# Altium schematic sheet led_clock-LEDB.SchDoc
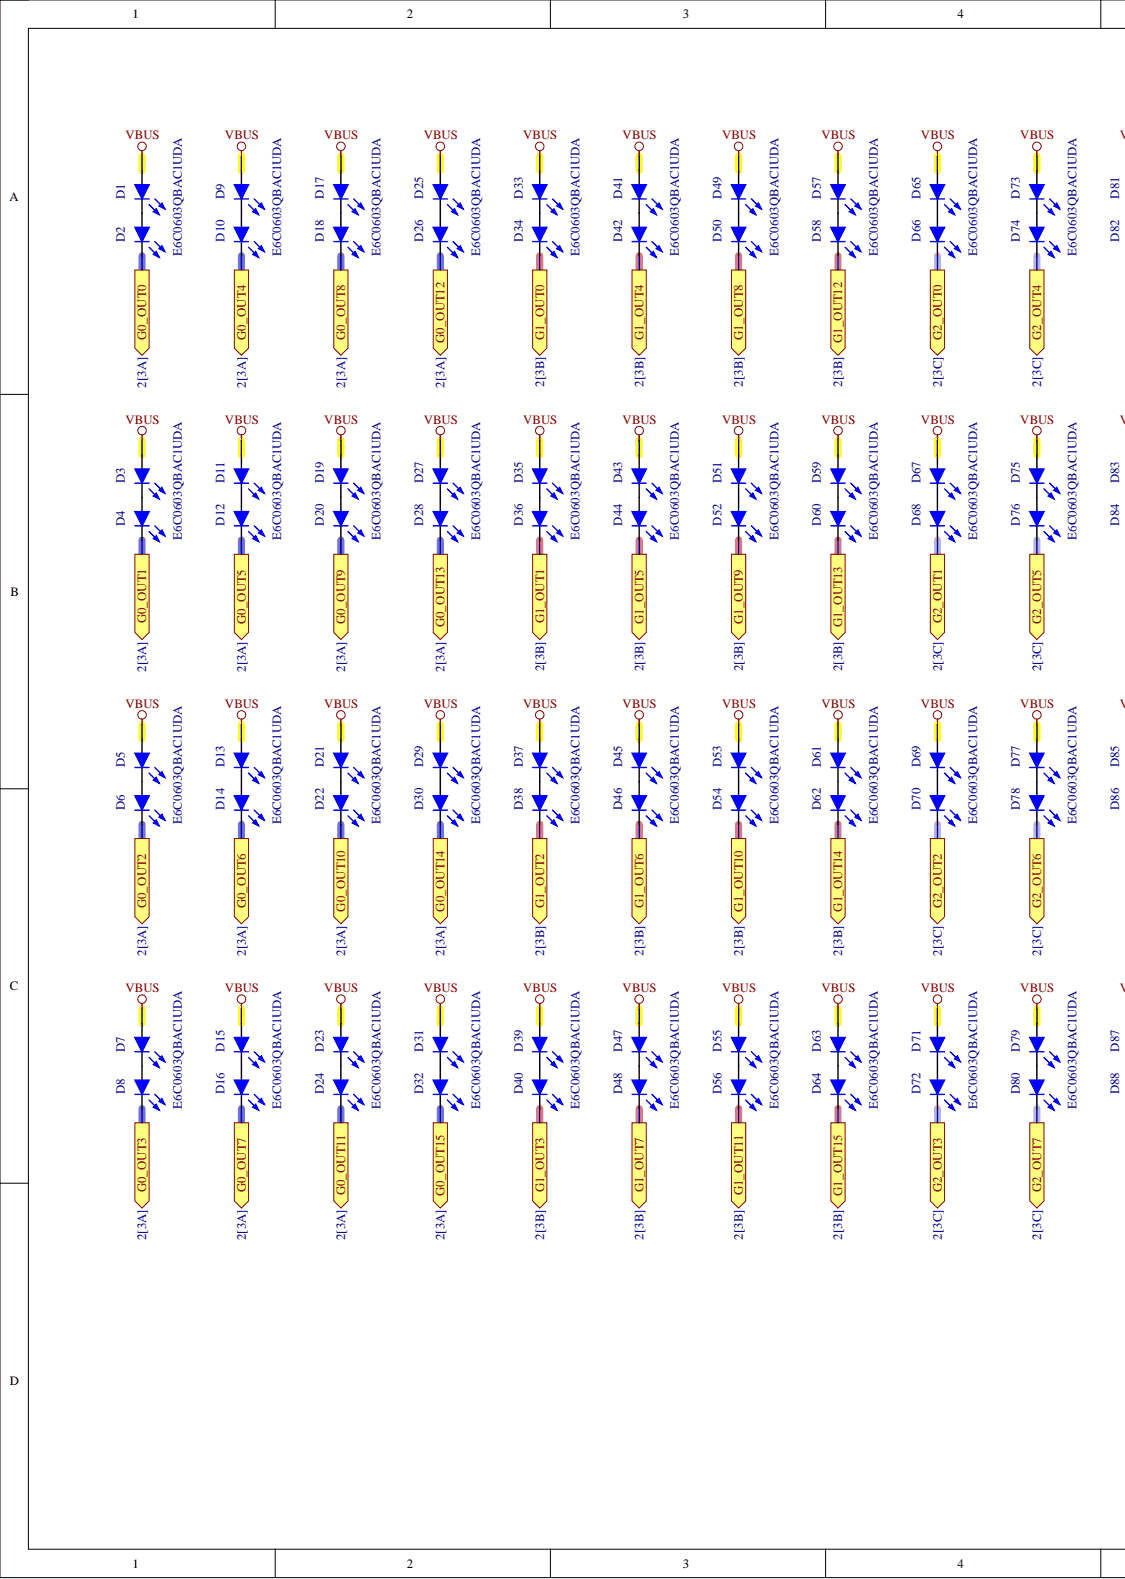
[diagram p0: led_clock-LEDB.SchDoc, left]
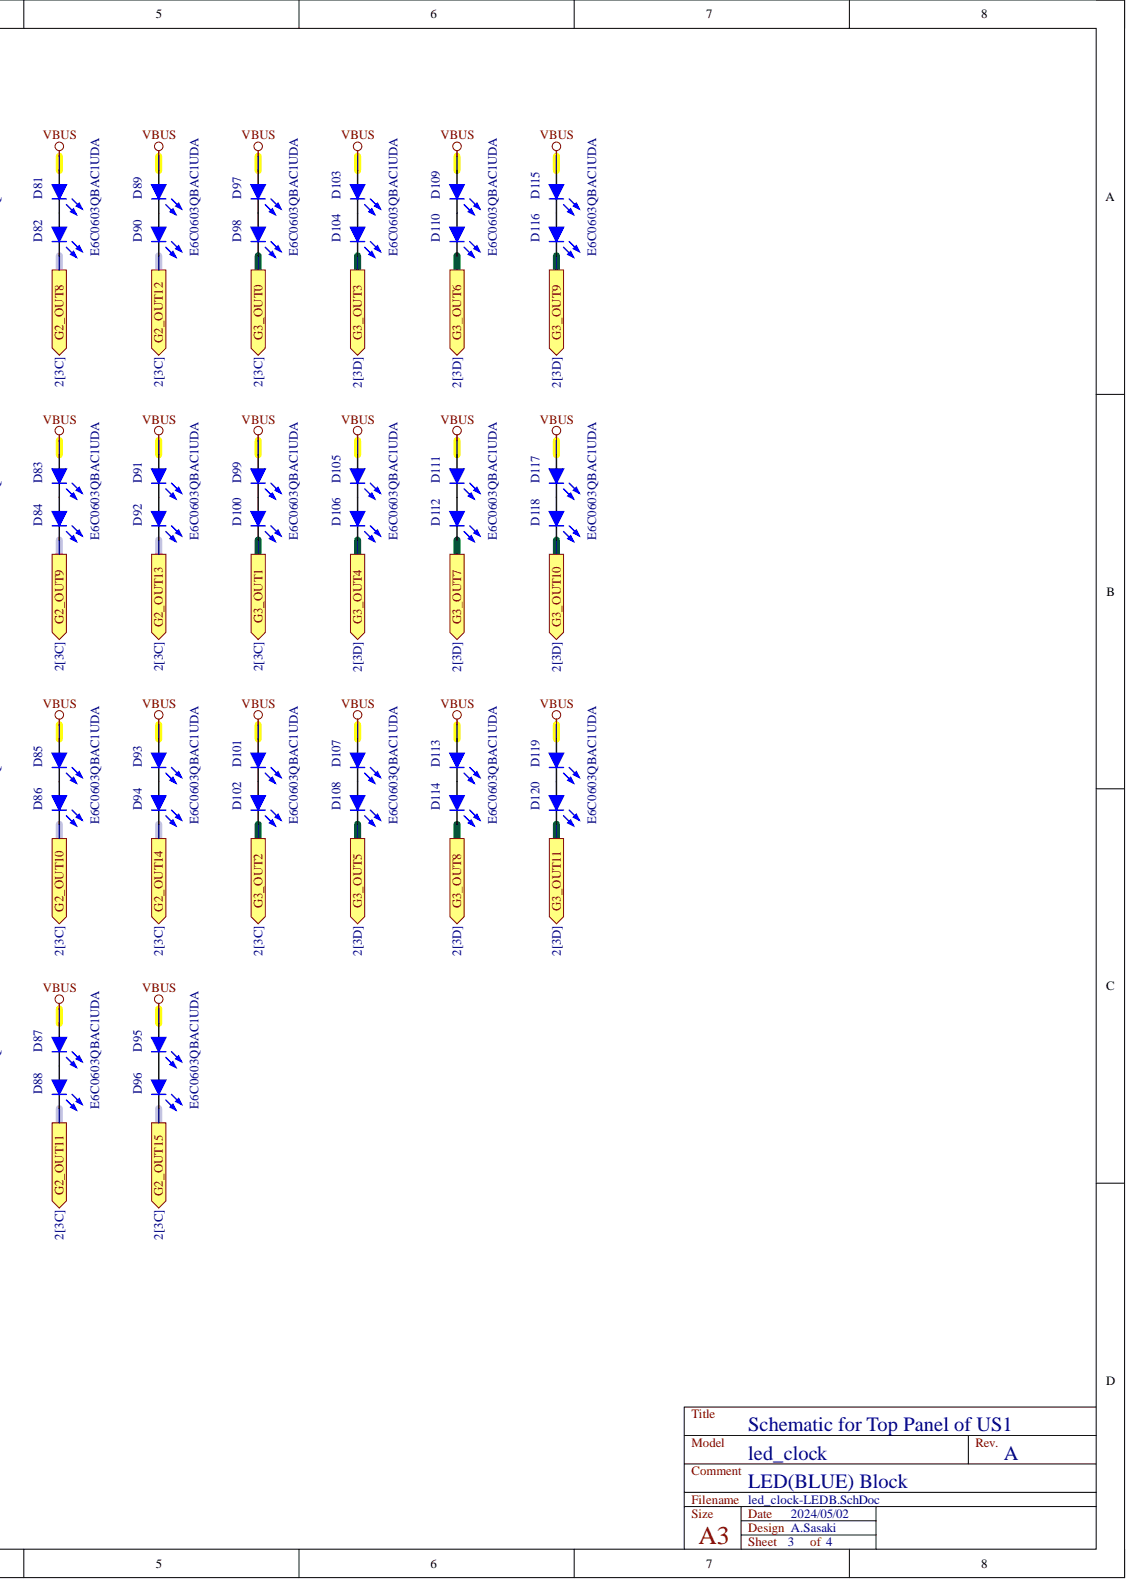
[diagram p1: led_clock-LEDB.SchDoc, right]

## Parts
D1  E6C0603QBAC1UDA  [LED1608X04N_ROTATED]
D2  E6C0603QBAC1UDA  [LED1608X04N_ROTATED]
D3  E6C0603QBAC1UDA  [LED1608X04N_ROTATED]
D4  E6C0603QBAC1UDA  [LED1608X04N_ROTATED]
D5  E6C0603QBAC1UDA  [LED1608X04N_ROTATED]
D6  E6C0603QBAC1UDA  [LED1608X04N_ROTATED]
D7  E6C0603QBAC1UDA  [LED1608X04N_ROTATED]
D8  E6C0603QBAC1UDA  [LED1608X04N_ROTATED]
D9  E6C0603QBAC1UDA  [LED1608X04N_ROTATED]
D10  E6C0603QBAC1UDA  [LED1608X04N_ROTATED]
D11  E6C0603QBAC1UDA  [LED1608X04N_ROTATED]
D12  E6C0603QBAC1UDA  [LED1608X04N_ROTATED]
D13  E6C0603QBAC1UDA  [LED1608X04N_ROTATED]
D14  E6C0603QBAC1UDA  [LED1608X04N_ROTATED]
D15  E6C0603QBAC1UDA  [LED1608X04N_ROTATED]
D16  E6C0603QBAC1UDA  [LED1608X04N_ROTATED]
D17  E6C0603QBAC1UDA  [LED1608X04N_ROTATED]
D18  E6C0603QBAC1UDA  [LED1608X04N_ROTATED]
D19  E6C0603QBAC1UDA  [LED1608X04N_ROTATED]
D20  E6C0603QBAC1UDA  [LED1608X04N_ROTATED]
D21  E6C0603QBAC1UDA  [LED1608X04N_ROTATED]
D22  E6C0603QBAC1UDA  [LED1608X04N_ROTATED]
D23  E6C0603QBAC1UDA  [LED1608X04N_ROTATED]
D24  E6C0603QBAC1UDA  [LED1608X04N_ROTATED]
D25  E6C0603QBAC1UDA  [LED1608X04N_ROTATED]
D26  E6C0603QBAC1UDA  [LED1608X04N_ROTATED]
D27  E6C0603QBAC1UDA  [LED1608X04N_ROTATED]
D28  E6C0603QBAC1UDA  [LED1608X04N_ROTATED]
D29  E6C0603QBAC1UDA  [LED1608X04N_ROTATED]
D30  E6C0603QBAC1UDA  [LED1608X04N_ROTATED]
D31  E6C0603QBAC1UDA  [LED1608X04N_ROTATED]
D32  E6C0603QBAC1UDA  [LED1608X04N_ROTATED]
D33  E6C0603QBAC1UDA  [LED1608X04N_ROTATED]
D34  E6C0603QBAC1UDA  [LED1608X04N_ROTATED]
D35  E6C0603QBAC1UDA  [LED1608X04N_ROTATED]
D36  E6C0603QBAC1UDA  [LED1608X04N_ROTATED]
D37  E6C0603QBAC1UDA  [LED1608X04N_ROTATED]
D38  E6C0603QBAC1UDA  [LED1608X04N_ROTATED]
D39  E6C0603QBAC1UDA  [LED1608X04N_ROTATED]
D40  E6C0603QBAC1UDA  [LED1608X04N_ROTATED]
D41  E6C0603QBAC1UDA  [LED1608X04N_ROTATED]
D42  E6C0603QBAC1UDA  [LED1608X04N_ROTATED]
D43  E6C0603QBAC1UDA  [LED1608X04N_ROTATED]
D44  E6C0603QBAC1UDA  [LED1608X04N_ROTATED]
D45  E6C0603QBAC1UDA  [LED1608X04N_ROTATED]
D46  E6C0603QBAC1UDA  [LED1608X04N_ROTATED]
D47  E6C0603QBAC1UDA  [LED1608X04N_ROTATED]
D48  E6C0603QBAC1UDA  [LED1608X04N_ROTATED]
D49  E6C0603QBAC1UDA  [LED1608X04N_ROTATED]
D50  E6C0603QBAC1UDA  [LED1608X04N_ROTATED]
D51  E6C0603QBAC1UDA  [LED1608X04N_ROTATED]
D52  E6C0603QBAC1UDA  [LED1608X04N_ROTATED]
D53  E6C0603QBAC1UDA  [LED1608X04N_ROTATED]
D54  E6C0603QBAC1UDA  [LED1608X04N_ROTATED]
D55  E6C0603QBAC1UDA  [LED1608X04N_ROTATED]
D56  E6C0603QBAC1UDA  [LED1608X04N_ROTATED]
D57  E6C0603QBAC1UDA  [LED1608X04N_ROTATED]
D58  E6C0603QBAC1UDA  [LED1608X04N_ROTATED]
D59  E6C0603QBAC1UDA  [LED1608X04N_ROTATED]
D60  E6C0603QBAC1UDA  [LED1608X04N_ROTATED]
D61  E6C0603QBAC1UDA  [LED1608X04N_ROTATED]
D62  E6C0603QBAC1UDA  [LED1608X04N_ROTATED]
D63  E6C0603QBAC1UDA  [LED1608X04N_ROTATED]
D64  E6C0603QBAC1UDA  [LED1608X04N_ROTATED]
D65  E6C0603QBAC1UDA  [LED1608X04N_ROTATED]
D66  E6C0603QBAC1UDA  [LED1608X04N_ROTATED]
D67  E6C0603QBAC1UDA  [LED1608X04N_ROTATED]
D68  E6C0603QBAC1UDA  [LED1608X04N_ROTATED]
D69  E6C0603QBAC1UDA  [LED1608X04N_ROTATED]
D70  E6C0603QBAC1UDA  [LED1608X04N_ROTATED]
D71  E6C0603QBAC1UDA  [LED1608X04N_ROTATED]
D72  E6C0603QBAC1UDA  [LED1608X04N_ROTATED]
D73  E6C0603QBAC1UDA  [LED1608X04N_ROTATED]
D74  E6C0603QBAC1UDA  [LED1608X04N_ROTATED]
D75  E6C0603QBAC1UDA  [LED1608X04N_ROTATED]
D76  E6C0603QBAC1UDA  [LED1608X04N_ROTATED]
D77  E6C0603QBAC1UDA  [LED1608X04N_ROTATED]
D78  E6C0603QBAC1UDA  [LED1608X04N_ROTATED]
D79  E6C0603QBAC1UDA  [LED1608X04N_ROTATED]
D80  E6C0603QBAC1UDA  [LED1608X04N_ROTATED]
D81  E6C0603QBAC1UDA  [LED1608X04N_ROTATED]
D82  E6C0603QBAC1UDA  [LED1608X04N_ROTATED]
D83  E6C0603QBAC1UDA  [LED1608X04N_ROTATED]
D84  E6C0603QBAC1UDA  [LED1608X04N_ROTATED]
D85  E6C0603QBAC1UDA  [LED1608X04N_ROTATED]
D86  E6C0603QBAC1UDA  [LED1608X04N_ROTATED]
D87  E6C0603QBAC1UDA  [LED1608X04N_ROTATED]
D88  E6C0603QBAC1UDA  [LED1608X04N_ROTATED]
D89  E6C0603QBAC1UDA  [LED1608X04N_ROTATED]
D90  E6C0603QBAC1UDA  [LED1608X04N_ROTATED]
D91  E6C0603QBAC1UDA  [LED1608X04N_ROTATED]
D92  E6C0603QBAC1UDA  [LED1608X04N_ROTATED]
D93  E6C0603QBAC1UDA  [LED1608X04N_ROTATED]
D94  E6C0603QBAC1UDA  [LED1608X04N_ROTATED]
D95  E6C0603QBAC1UDA  [LED1608X04N_ROTATED]
D96  E6C0603QBAC1UDA  [LED1608X04N_ROTATED]
D97  E6C0603QBAC1UDA  [LED1608X04N_ROTATED]
D98  E6C0603QBAC1UDA  [LED1608X04N_ROTATED]
D99  E6C0603QBAC1UDA  [LED1608X04N_ROTATED]
D100  E6C0603QBAC1UDA  [LED1608X04N_ROTATED]
D101  E6C0603QBAC1UDA  [LED1608X04N_ROTATED]
D102  E6C0603QBAC1UDA  [LED1608X04N_ROTATED]
D103  E6C0603QBAC1UDA  [LED1608X04N_ROTATED]
D104  E6C0603QBAC1UDA  [LED1608X04N_ROTATED]
D105  E6C0603QBAC1UDA  [LED1608X04N_ROTATED]
D106  E6C0603QBAC1UDA  [LED1608X04N_ROTATED]
D107  E6C0603QBAC1UDA  [LED1608X04N_ROTATED]
D108  E6C0603QBAC1UDA  [LED1608X04N_ROTATED]
D109  E6C0603QBAC1UDA  [LED1608X04N_ROTATED]
D110  E6C0603QBAC1UDA  [LED1608X04N_ROTATED]
D111  E6C0603QBAC1UDA  [LED1608X04N_ROTATED]
D112  E6C0603QBAC1UDA  [LED1608X04N_ROTATED]
D113  E6C0603QBAC1UDA  [LED1608X04N_ROTATED]
D114  E6C0603QBAC1UDA  [LED1608X04N_ROTATED]
D115  E6C0603QBAC1UDA  [LED1608X04N_ROTATED]
D116  E6C0603QBAC1UDA  [LED1608X04N_ROTATED]
D117  E6C0603QBAC1UDA  [LED1608X04N_ROTATED]
D118  E6C0603QBAC1UDA  [LED1608X04N_ROTATED]
D119  E6C0603QBAC1UDA  [LED1608X04N_ROTATED]
D120  E6C0603QBAC1UDA  [LED1608X04N_ROTATED]

## Ports
G0_OUT0, G0_OUT1, G0_OUT2, G0_OUT3, G0_OUT4, G0_OUT5, G0_OUT6, G0_OUT7, G0_OUT8, G0_OUT9, G0_OUT10, G0_OUT11, G0_OUT12, G0_OUT13, G0_OUT14, G0_OUT15, G1_OUT0, G1_OUT1, G1_OUT2, G1_OUT3, G1_OUT4, G1_OUT5, G1_OUT6, G1_OUT7, G1_OUT8, G1_OUT9, G1_OUT10, G1_OUT11, G1_OUT12, G1_OUT13, G1_OUT14, G1_OUT15, G2_OUT0, G2_OUT1, G2_OUT2, G2_OUT3, G2_OUT4, G2_OUT5, G2_OUT6, G2_OUT7, G2_OUT8, G2_OUT9, G2_OUT10, G2_OUT11, G2_OUT12, G2_OUT13, G2_OUT14, G2_OUT15, G3_OUT0, G3_OUT1, G3_OUT2, G3_OUT3, G3_OUT4, G3_OUT5, G3_OUT6, G3_OUT7, G3_OUT8, G3_OUT9, G3_OUT10, G3_OUT11

## Nets
VBUS: D1.1 D3.1 D5.1 D7.1 D9.1 D11.1 D13.1 D15.1 D17.1 D19.1 D21.1 D23.1 D25.1 D27.1 D29.1 D31.1 D33.1 D35.1 D37.1 D39.1 D41.1 D43.1 D45.1 D47.1 D49.1 D51.1 D53.1 D55.1 D57.1 D59.1 D61.1 D63.1 D65.1 D67.1 D69.1 D71.1 D73.1 D75.1 D77.1 D79.1 D81.1 D83.1 D85.1 D87.1 D89.1 D91.1 D93.1 D95.1 D97.1 D99.1 D101.1 D103.1 D105.1 D107.1 D109.1 D111.1 D113.1 D115.1 D117.1 D119.1
G0_OUT0: D2.2 ; port G0_OUT0
G0_OUT1: D4.2 ; port G0_OUT1
G0_OUT2: D6.2 ; port G0_OUT2
G0_OUT3: D8.2 ; port G0_OUT3
G0_OUT4: D10.2 ; port G0_OUT4
G0_OUT5: D12.2 ; port G0_OUT5
G0_OUT6: D14.2 ; port G0_OUT6
G0_OUT7: D16.2 ; port G0_OUT7
G0_OUT8: D18.2 ; port G0_OUT8
G0_OUT9: D20.2 ; port G0_OUT9
G0_OUT10: D22.2 ; port G0_OUT10
G0_OUT11: D24.2 ; port G0_OUT11
G0_OUT12: D26.2 ; port G0_OUT12
G0_OUT13: D28.2 ; port G0_OUT13
G0_OUT14: D30.2 ; port G0_OUT14
G0_OUT15: D32.2 ; port G0_OUT15
G1_OUT0: D34.2 ; port G1_OUT0
G1_OUT1: D36.2 ; port G1_OUT1
G1_OUT2: D38.2 ; port G1_OUT2
G1_OUT3: D40.2 ; port G1_OUT3
G1_OUT4: D42.2 ; port G1_OUT4
G1_OUT5: D44.2 ; port G1_OUT5
G1_OUT6: D46.2 ; port G1_OUT6
G1_OUT7: D48.2 ; port G1_OUT7
G1_OUT8: D50.2 ; port G1_OUT8
G1_OUT9: D52.2 ; port G1_OUT9
G1_OUT10: D54.2 ; port G1_OUT10
G1_OUT11: D56.2 ; port G1_OUT11
G1_OUT12: D58.2 ; port G1_OUT12
G1_OUT13: D60.2 ; port G1_OUT13
G1_OUT14: D62.2 ; port G1_OUT14
G1_OUT15: D64.2 ; port G1_OUT15
G2_OUT0: D66.2 ; port G2_OUT0
G2_OUT1: D68.2 ; port G2_OUT1
G2_OUT2: D70.2 ; port G2_OUT2
G2_OUT3: D72.2 ; port G2_OUT3
G2_OUT4: D74.2 ; port G2_OUT4
G2_OUT5: D76.2 ; port G2_OUT5
G2_OUT6: D78.2 ; port G2_OUT6
G2_OUT7: D80.2 ; port G2_OUT7
G2_OUT8: D82.2 ; port G2_OUT8
G2_OUT9: D84.2 ; port G2_OUT9
G2_OUT10: D86.2 ; port G2_OUT10
G2_OUT11: D88.2 ; port G2_OUT11
G2_OUT12: D90.2 ; port G2_OUT12
G2_OUT13: D92.2 ; port G2_OUT13
G2_OUT14: D94.2 ; port G2_OUT14
G2_OUT15: D96.2 ; port G2_OUT15
G3_OUT0: D98.2 ; port G3_OUT0
G3_OUT1: D100.2 ; port G3_OUT1
G3_OUT2: D102.2 ; port G3_OUT2
G3_OUT3: D104.2 ; port G3_OUT3
G3_OUT4: D106.2 ; port G3_OUT4
G3_OUT5: D108.2 ; port G3_OUT5
G3_OUT6: D110.2 ; port G3_OUT6
G3_OUT7: D112.2 ; port G3_OUT7
G3_OUT8: D114.2 ; port G3_OUT8
G3_OUT9: D116.2 ; port G3_OUT9
G3_OUT10: D118.2 ; port G3_OUT10
G3_OUT11: D120.2 ; port G3_OUT11
NetD1_2: D1.2 D2.1
NetD3_2: D3.2 D4.1
NetD5_2: D5.2 D6.1
NetD7_2: D7.2 D8.1
NetD9_2: D9.2 D10.1
NetD11_2: D11.2 D12.1
NetD13_2: D13.2 D14.1
NetD15_2: D15.2 D16.1
NetD17_2: D17.2 D18.1
NetD19_2: D19.2 D20.1
NetD21_2: D21.2 D22.1
NetD23_2: D23.2 D24.1
NetD25_2: D25.2 D26.1
NetD27_2: D27.2 D28.1
NetD29_2: D29.2 D30.1
NetD31_2: D31.2 D32.1
NetD33_2: D33.2 D34.1
NetD35_2: D35.2 D36.1
NetD37_2: D37.2 D38.1
NetD39_2: D39.2 D40.1
NetD41_2: D41.2 D42.1
NetD43_2: D43.2 D44.1
NetD45_2: D45.2 D46.1
NetD47_2: D47.2 D48.1
NetD49_2: D49.2 D50.1
NetD51_2: D51.2 D52.1
NetD53_2: D53.2 D54.1
NetD55_2: D55.2 D56.1
NetD57_2: D57.2 D58.1
NetD59_2: D59.2 D60.1
NetD61_2: D61.2 D62.1
NetD63_2: D63.2 D64.1
NetD65_2: D65.2 D66.1
NetD67_2: D67.2 D68.1
NetD69_2: D69.2 D70.1
NetD71_2: D71.2 D72.1
NetD73_2: D73.2 D74.1
NetD75_2: D75.2 D76.1
NetD77_2: D77.2 D78.1
NetD79_2: D79.2 D80.1
NetD81_2: D81.2 D82.1
NetD83_2: D83.2 D84.1
NetD85_2: D85.2 D86.1
NetD87_2: D87.2 D88.1
NetD89_2: D89.2 D90.1
NetD91_2: D91.2 D92.1
NetD93_2: D93.2 D94.1
NetD95_2: D95.2 D96.1
NetD97_2: D97.2 D98.1
NetD99_2: D99.2 D100.1
NetD101_2: D101.2 D102.1
NetD103_2: D103.2 D104.1
NetD105_2: D105.2 D106.1
NetD107_2: D107.2 D108.1
NetD109_2: D109.2 D110.1
NetD111_2: D111.2 D112.1
NetD113_2: D113.2 D114.1
NetD115_2: D115.2 D116.1
NetD117_2: D117.2 D118.1
NetD119_2: D119.2 D120.1

## Library data of the parts
D1-D120 = E6C0603QBAC1UDA: 1.6 x 0.8 x 0.55mm SMD 465nm BLUE LED; Manufacturer: EKINGLUX; Component Kind: Standard; LCSC Part Number: C375446; Library Name: Ekinglux.IntLib; Manufacturer Part Number: E6C0603QBAC1UDA; PackageDescription: LED; 1608; Published: 2022/08/19; Publisher: Atsushi Sasaki
